# Altium schematic sheet TD4-CORE.SchDoc(TD4-CORE)
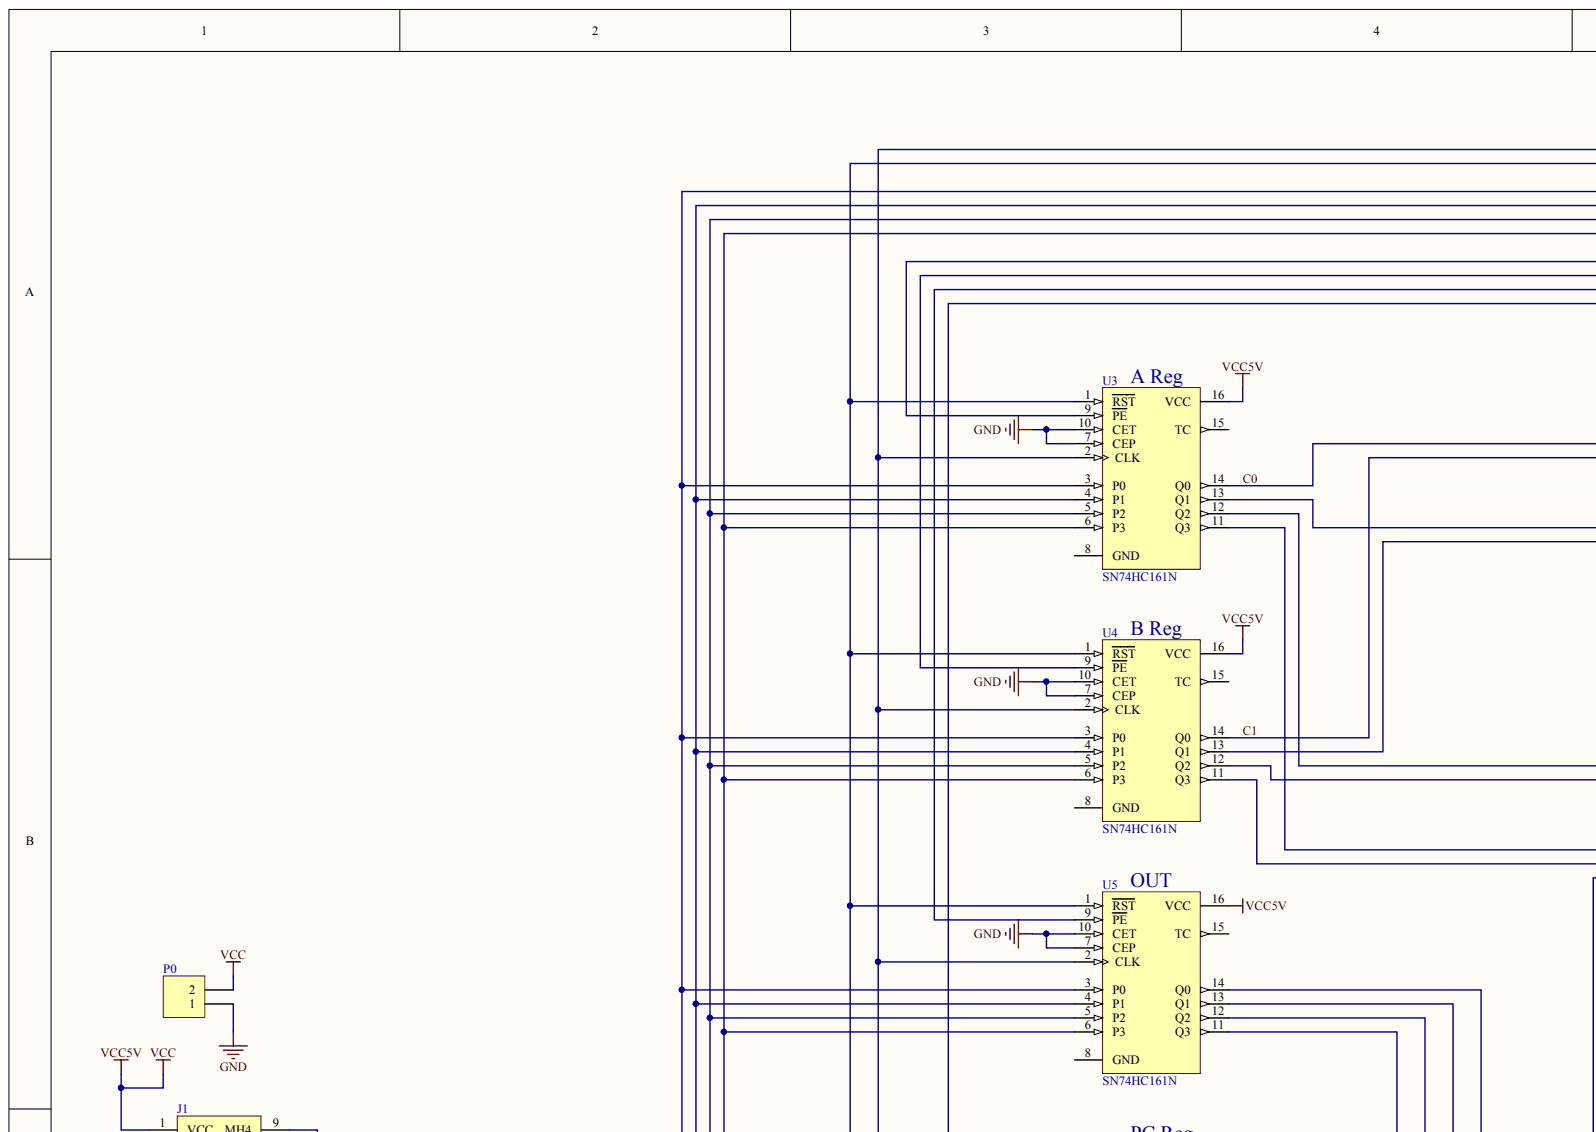
[diagram p0: TD4-CORE.SchDoc, top left]
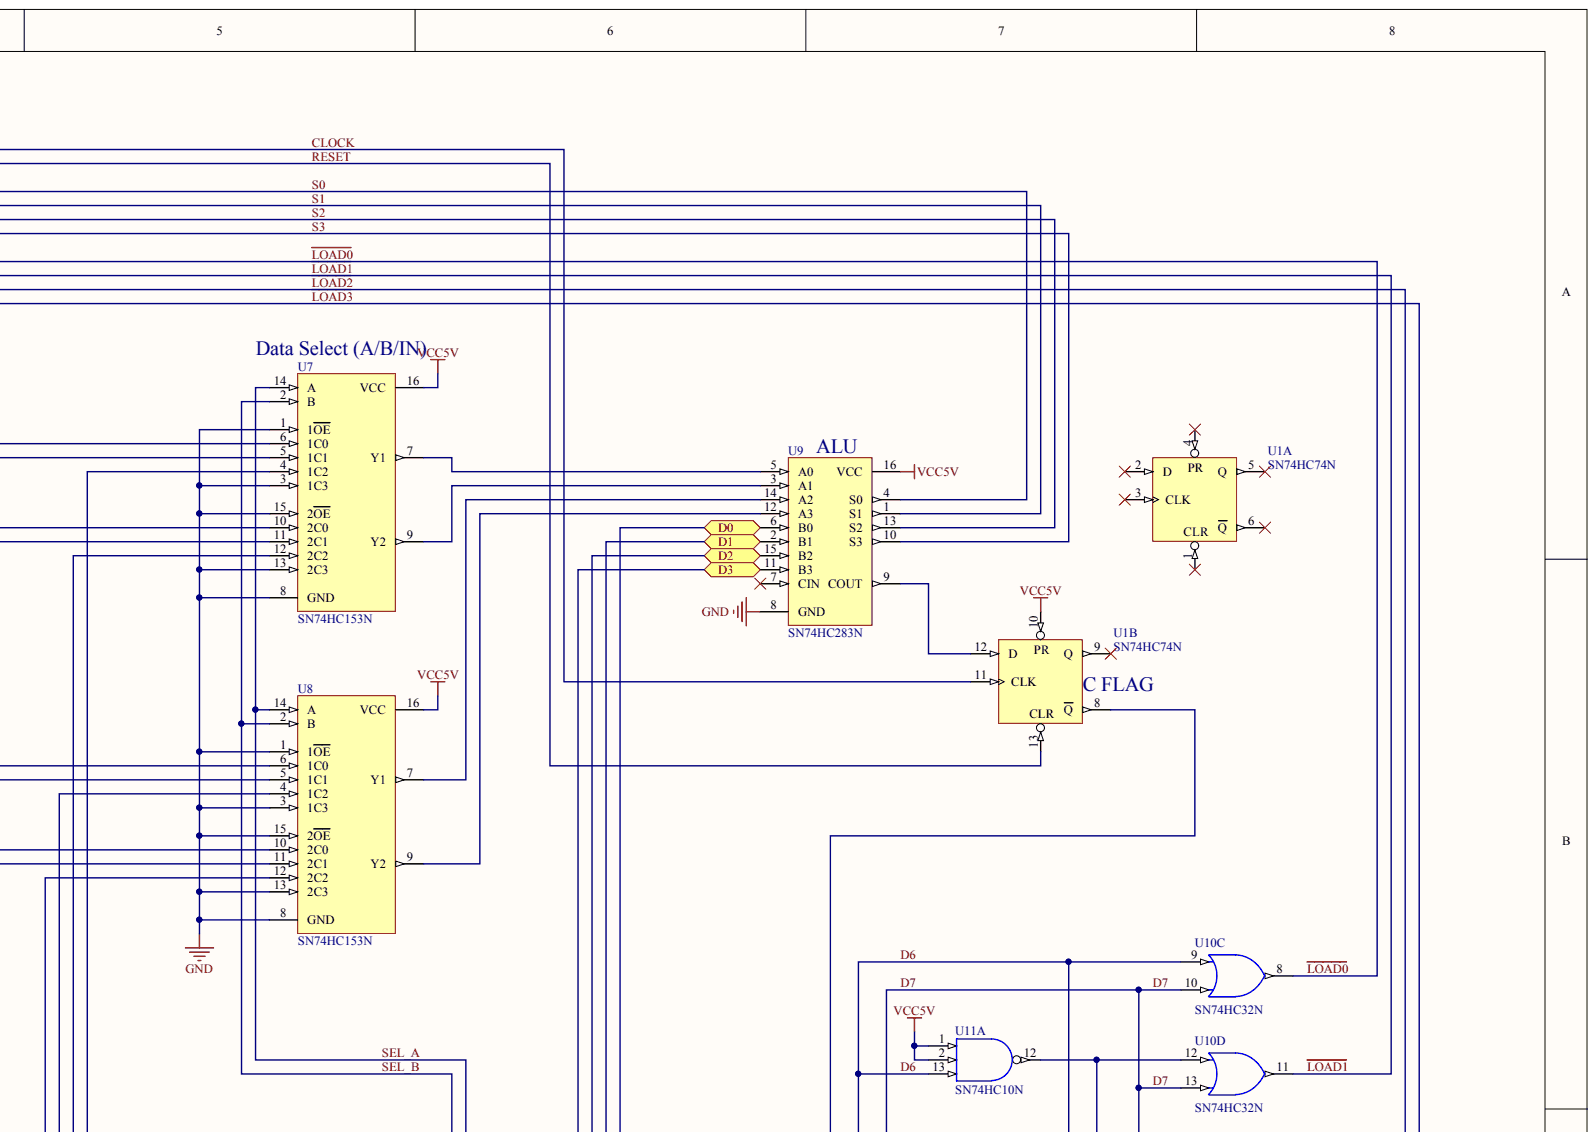
[diagram p1: TD4-CORE.SchDoc, top right]
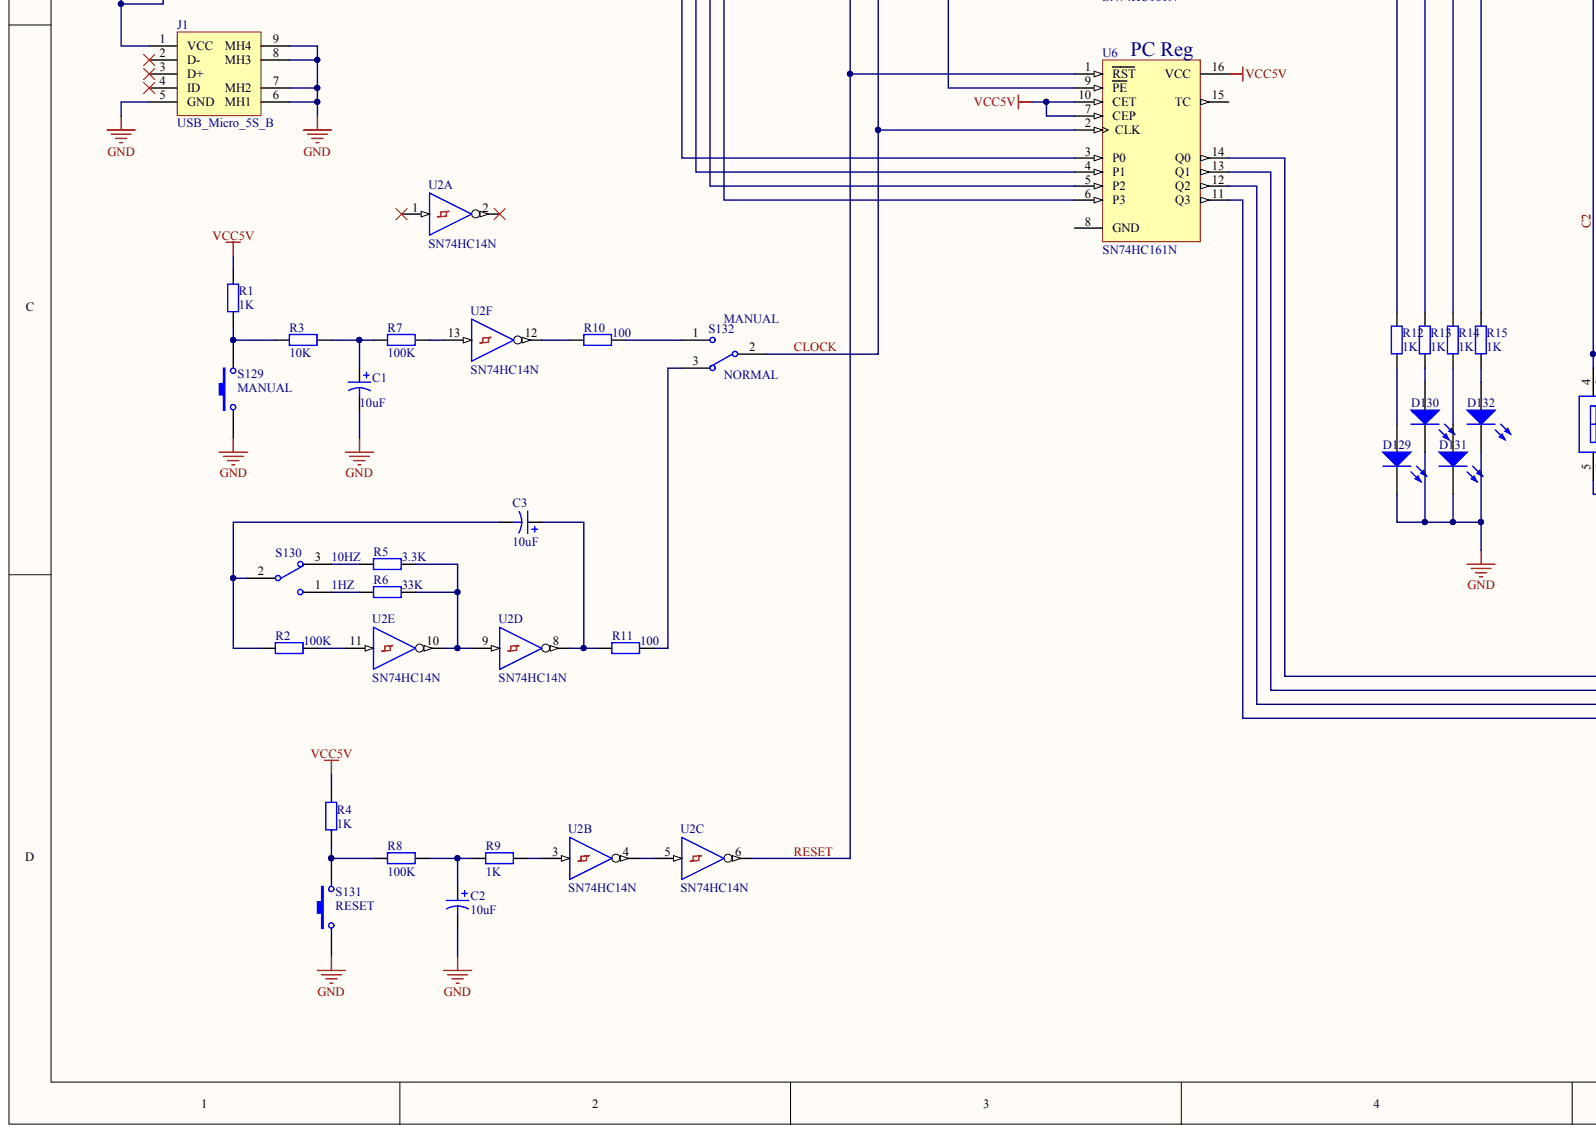
[diagram p2: TD4-CORE.SchDoc, bottom left]
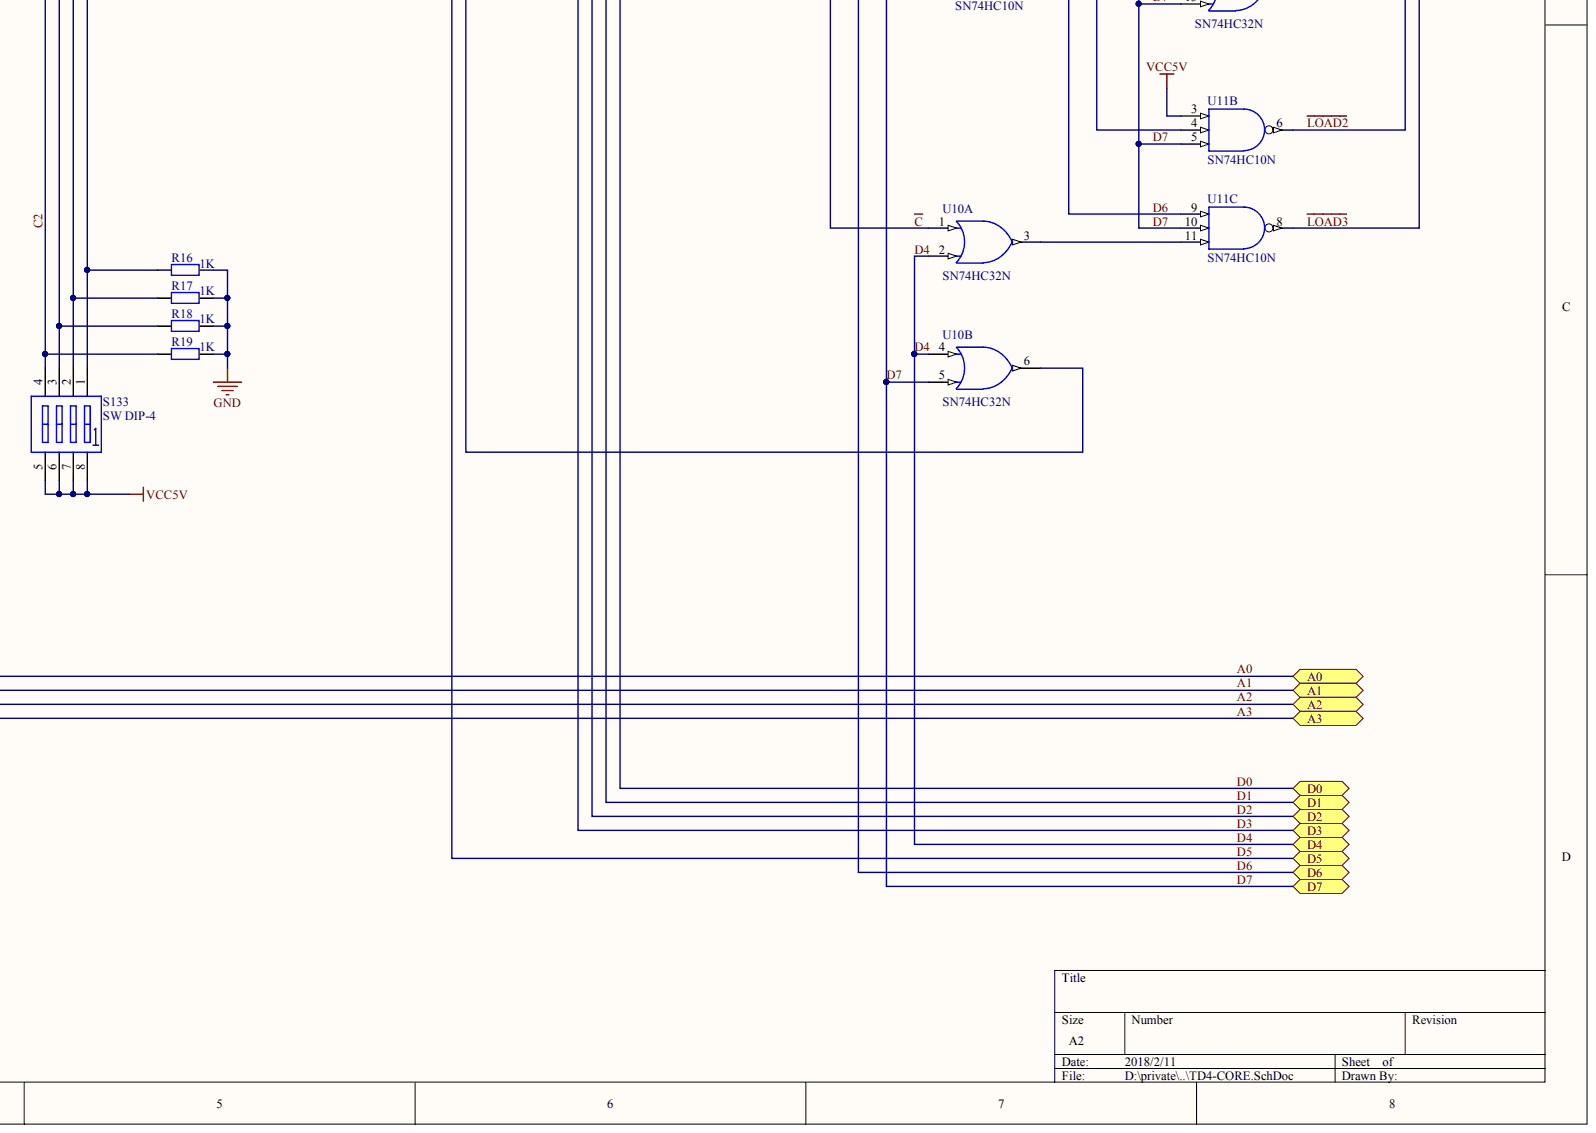
[diagram p3: TD4-CORE.SchDoc, bottom right]

## Parts
C1  value 10uF  [CAP1.5_4x7]  (hidden comment: Cap Pol1)
C2  value 10uF  [CAP1.5_4x7]  (hidden comment: Cap Pol1)
C3  value 10uF  [CAP1.5_4x7]  (hidden comment: Cap Pol1)
D129  LED0  [LED]  (value not drawn)
D130  LED0  [LED]  (value not drawn)
D131  LED0  [LED]  (value not drawn)
D132  LED0  [LED]  (value not drawn)
J1  USB_Micro_5S_B  [USB_MICRO_5S_B]
P0  Header 2  [HDR1X2]  (value not drawn)
R1  value 1K  [AXIAL-0.4]  (hidden comment: Res2)
R2  value 100K  [AXIAL-0.4]  (hidden comment: Res2)
R3  value 10K  [AXIAL-0.4]  (hidden comment: Res2)
R4  value 1K  [AXIAL-0.4]  (hidden comment: Res2)
R5  value 3.3K  [AXIAL-0.4]  (hidden comment: Res2)
R6  value 33K  [AXIAL-0.4]  (hidden comment: Res2)
R7  value 100K  [AXIAL-0.4]  (hidden comment: Res2)
R8  value 100K  [AXIAL-0.4]  (hidden comment: Res2)
R9  value 1K  [AXIAL-0.4]  (hidden comment: Res2)
R10  value 100  [AXIAL-0.4]  (hidden comment: Res2)
R11  value 100  [AXIAL-0.4]  (hidden comment: Res2)
R12  value 1K  [AXIAL-0.4]  (hidden comment: Res2)
R13  value 1K  [AXIAL-0.4]  (hidden comment: Res2)
R14  value 1K  [AXIAL-0.4]  (hidden comment: Res2)
R15  value 1K  [AXIAL-0.4]  (hidden comment: Res2)
R16  value 1K  [AXIAL-0.4]  (hidden comment: Res2)
R17  value 1K  [AXIAL-0.4]  (hidden comment: Res2)
R18  value 1K  [AXIAL-0.4]  (hidden comment: Res2)
R19  value 1K  [AXIAL-0.4]  (hidden comment: Res2)
S129  MANUAL  [TSW DIP-6*6*6]
S130  SW-SPDT  [SS-12F23]  (value not drawn)
S131  RESET  [TSW DIP-6*6*6]
S132  SW-SPDT  [SS-12F23]  (value not drawn)
S133  SW DIP-4  [CSW DIP-4P]
U1  SN74HC74N  [N014]  (parts A,B)
U2  SN74HC14N  [N014]  (parts A,B,C,D,E,F)
U3  SN74HC161N  [N016]
U4  SN74HC161N  [N016]
U5  SN74HC161N  [N016]
U6  SN74HC161N  [N016]
U7  SN74HC153N  [N016]
U8  SN74HC153N  [N016]
U9  SN74HC283N  [N016]
U10  SN74HC32N  [N014]  (parts A,B,C,D)
U11  SN74HC10N  [N014]  (parts A,B,C)

## Ports
A0, A1, A2, A3, D0, D1, D2, D3, D4, D5, D6, D7

## Nets
GND: C1.2 C2.2 D129.2 D130.2 D131.2 D132.2 J1.5(GND) J1.6(MH1) J1.7(MH2) J1.8(MH3) J1.9(MH4) P0.1 R16.2 R17.2 R18.2 R19.2 S129.1 S131.1 U1.7 U2.7 U3.7(CEP) U3.10(CET) U4.7(CEP) U4.10(CET) U5.7(CEP) U5.10(CET) U7.1(1OE) U7.3(1C3) U7.8(GND) U7.13(2C3) U7.15(2OE) U8.1(1OE) U8.3(1C3) U8.8(GND) U8.13(2C3) U8.15(2OE) U9.8(GND) U10.7 U11.7
VCC: J1.1(VCC) P0.2 R1.1 R4.1 S133.5 S133.6 S133.7 S133.8 U1.10(PR) U1.14 U2.14 U3.16(VCC) U4.16(VCC) U5.16(VCC) U6.7(CEP) U6.10(CET) U6.16(VCC) U7.16(VCC) U8.16(VCC) U9.16(VCC) U10.14 U11.1 U11.2 U11.3 U11.14
A0: U6.14(Q0) ; port A0
A1: U6.13(Q1) ; port A1
A2: U6.12(Q2) ; port A2
A3: U6.11(Q3) ; port A3
C0: U3.14(Q0) U7.6(1C0)
C1: U4.14(Q0) U7.5(1C1)
C2: R19.1 S133.4 U8.12(2C2)
~{C}: U1.8(Q) U10.1
CLOCK: S132.2 U1.11(CLK) U3.2(CLK) U4.2(CLK) U5.2(CLK) U6.2(CLK)
D0: U9.6(B0) ; port D0
D1: U9.2(B1) ; port D1
D2: U9.15(B2) ; port D2
D3: U9.11(B3) ; port D3
D4: U10.2 U10.4 ; port D4
D5: U7.2(B) U8.2(B) ; port D5
D6: U10.9 U11.9 U11.13 ; port D6
D7: U10.5 U10.10 U10.13 U11.5 U11.10 ; port D7
~{LOAD0}: U3.9(PE) U10.8
~{LOAD1}: U4.9(PE) U10.11
~{LOAD2}: U5.9(PE) U11.6
~{LOAD3}: U6.9(PE) U11.8
RESET: U1.13 U2.6 U3.1(RST) U4.1(RST) U5.1(RST) U6.1(RST)
S0: U3.3(P0) U4.3(P0) U5.3(P0) U6.3(P0) U9.4(S0)
S1: U3.4(P1) U4.4(P1) U5.4(P1) U6.4(P1) U9.1(S1)
S2: U3.5(P2) U4.5(P2) U5.5(P2) U6.5(P2) U9.13(S2)
S3: U3.6(P3) U4.6(P3) U5.6(P3) U6.6(P3) U9.10(S3)
SEL_A: U7.14(A) U8.14(A) U10.6
NetC1_1: C1.1 R3.2 R7.1
NetC2_1: C2.1 R8.2 R9.1
NetC3_1: C3.1 R11.1 U2.8
NetC3_2: C3.2 R2.1 S130.2
NetD129_1: D129.1 R12.2
NetD130_1: D130.1 R13.2
NetD131_1: D131.1 R14.2
NetD132_1: D132.1 R15.2
NetR1_2: R1.2 R3.1 S129.2
NetR2_2: R2.2 U2.11
NetR4_2: R4.2 R8.1 S131.2
NetR5_1: R5.1 S130.3
NetR5_2: R5.2 R6.2 U2.9 U2.10
NetR6_1: R6.1 S130.1
NetR7_2: R7.2 U2.13
NetR9_2: R9.2 U2.3
NetR10_1: R10.1 U2.12
NetR10_2: R10.2 S132.1
NetR11_2: R11.2 S132.3
NetR12_1: R12.1 U5.11(Q3)
NetR13_1: R13.1 U5.12(Q2)
NetR14_1: R14.1 U5.13(Q1)
NetR15_1: R15.1 U5.14(Q0)
NetR16_1: R16.1 S133.1 U7.4(1C2)
NetR17_1: R17.1 S133.2 U7.12(2C2)
NetR18_1: R18.1 S133.3 U8.4(1C2)
NetU1_12: U1.12(D) U9.9(COUT)
NetU2_4: U2.4 U2.5
NetU3_11: U3.11(Q3) U8.10(2C0)
NetU3_12: U3.12(Q2) U8.6(1C0)
NetU3_13: U3.13(Q1) U7.10(2C0)
NetU4_11: U4.11(Q3) U8.11(2C1)
NetU4_12: U4.12(Q2) U8.5(1C1)
NetU4_13: U4.13(Q1) U7.11(2C1)
NetU7_7: U7.7(Y1) U9.5(A0)
NetU7_9: U7.9(Y2) U9.3(A1)
NetU8_7: U8.7(Y1) U9.14(A2)
NetU8_9: U8.9(Y2) U9.12(A3)
NetU10_3: U10.3 U11.11
NetU10_12: U10.12 U11.4 U11.12

Not connected: J1 2(D-) 3(D+) 4(ID); U1 1 2(D) 3(CLK) 4(PR) 5(Q) 6(Q) 9(Q); U2 1 2; U3 8(GND) 15(TC); U4 8(GND) 15(TC); U5 8(GND) 15(TC); U6 8(GND) 15(TC); U9 7(CIN)

## Library data of the parts
C1-C3 = Cap Pol1: Polarized Capacitor (Radial); Component Kind: Standard; LatestRevisionDate: 17-Jul-2002; LatestRevisionNote: Re-released for DXP Platform.; Library Name: Miscellaneous Devices.IntLib; PackageDescription: Polarized Capacitor (Radial); 2 Leads; PackageReference: RB7.6-15; Published: 8-Jun-2000; Publisher: Altium Limited
D129-D132 = LED0: Typical INFRARED GaAs LED; Component Kind: Standard; LatestRevisionDate: 08-Jul-2005; LatestRevisionNote: Mech Layer 1 removed.; Library Name: Miscellaneous Devices.IntLib; PackageDescription: LED; 2 Leads; PackageReference: LED-0; Published: 8-Jun-2000; Simulation: LED0; Publisher: Altium Limited
P0 = Header 2: Header, 2-Pin; Component Kind: Standard; LatestRevisionDate: 17-Jul-2002; LatestRevisionNote: Re-released for DXP Platform.; Library Name: Miscellaneous Connectors.IntLib; Signal Integrity: Connector; Publisher: Altium Limited
R1-R19 = Res2: Resistor; Component Kind: Standard; LatestRevisionDate: 17-Jul-2002; LatestRevisionNote: Re-released for DXP Platform.; Library Name: Miscellaneous Devices.IntLib; PackageDescription: Axial Device, Thru-Hole; 2 Leads; 0.4 in Pin Spacing; PackageReference: AXIAL-0.4; Published: 8-Jun-2000; Signal Integrity: Res; Simulation: RESISTOR; Publisher: Altium Limited
S129 S131 = SW-PB: Switch; Component Kind: Standard; LatestRevisionDate: 17-Jul-2002; LatestRevisionNote: Re-released for DXP Platform.; Library Name: Miscellaneous Devices.IntLib; PackageDescription: Switch; 2 Leads; PackageReference: SPST-2; Published: 24-Mar-1999; Publisher: Altium Limited
S130 S132 = SW-SPDT: SPDT Subminiature Toggle Switch, Right Angle Mounting, Vertical Actuation; Component Kind: Standard; ComponentLink1Description: Manufacturer Link; ComponentLink1URL: http://www.apem.com; DatasheetVersion: 05-Dec-2000; LatestRevisionDate: 17-Dec-2003; LatestRevisionNote: Schematic revised, PCB Footprint replaced.; Library Name: Miscellaneous Devices.IntLib; PackageDescription: Switch; 4 Leads; PackageReference: TL36WW15050; Published: 24-Mar-1999; Publisher: Altium Limited
S133 = SW DIP-4: DIP Switch, 4 Position, SPST; Code_IPC: SOIC127P600-8; Code_JEDEC: MS-012-AA; Component Kind: Standard; LatestRevisionDate: 29-May-2009; LatestRevisionNote: IPC-7351 Footprint Added.; Library Name: Miscellaneous Devices.IntLib; PackageDescription: 8-Pin Small Outline Integrated Circuit 1.27 mm Pitch; PackageReference: SO8; PackageVersion: Dec-2002; Published: 24-Mar-1999; Publisher: Altium Limited
U1 = SN74HC74N: Dual D-Type Positive-Edge-Triggered Flip-Flop with Clear and Preset; Component Kind: Standard; ComponentLink1Description: Manufacturer Link; ComponentLink1URL: http://www.ti.com/; ComponentLink2Description: Datasheet; ComponentLink2URL: http://www-s.ti.com/sc/ds/sn74hc74.pdf; DatasheetVersion: May-1997; LatestRevisionDate: 04-Mar-2005; LatestRevisionNote: Stylized 3D Model Added.; Library Name: TI Logic Flip-Flop.IntLib; PackageDescription: DIP; 14 Leads; Row Spacing 7.62 mm; Pitch 2.54 mm; PackageReference: N014; PackageVersion: Oct-1995; PCB3D: N014; Published: 8-Jun-2000; Signal Integrity: SN74HC74N; Publisher: Altium Limited
U2 = SN74HC14N: Hex Schmitt-Trigger Inverter; Component Kind: Standard; ComponentLink1Description: Manufacturer Link; ComponentLink1URL: http://www.ti.com/; ComponentLink2Description: Datasheet; ComponentLink2URL: http://www-s.ti.com/sc/ds/sn74hc14.pdf; DatasheetVersion: May-1997; LatestRevisionDate: 04-Mar-2005; LatestRevisionNote: Stylized 3D Model Added.; Library Name: TI Logic Gate 2.IntLib; PackageDescription: DIP; 14 Leads; Row Spacing 7.62 mm; Pitch 2.54 mm; PackageReference: N014; PackageVersion: Oct-1995; PCB3D: N014; Published: 8-Jun-2000; Signal Integrity: SN74HC14N; Publisher: Altium Limited
U3-U6 = SN74HC161N: 4-Bit Synchronous Binary Counter; Code_JEDEC: MS-001-BB; Component Kind: Standard; ComponentLink1Description: Manufacturer Link; ComponentLink1URL: http://www.ti.com/; ComponentLink2Description: Datasheet; ComponentLink2URL: http://www-s.ti.com/sc/ds/sn74hc161.pdf; DatasheetVersion: May-1997; LatestRevisionDate: 04-Mar-2005; LatestRevisionNote: Stylized 3D Model Added.; Library Name: TI Logic Counter.IntLib; PackageDescription: DIP; 16 Leads; Row Spacing 7.62 mm; Pitch 2.54 mm; PackageReference: N016; PackageVersion: Oct-1995; PCB3D: N016; Published: 8-Jun-2000; Signal Integrity: SN74HC161N; Publisher: Altium Limited
U7 U8 = SN74HC153N: Dual 4-Line to 1-Line Data Selector/Multiplexer; Code_JEDEC: MS-001-BB; Component Kind: Standard; ComponentLink1Description: Manufacturer Link; ComponentLink1URL: http://www.ti.com/; ComponentLink2Description: Datasheet; ComponentLink2URL: http://www-s.ti.com/sc/ds/sn74hc153.pdf; DatasheetVersion: May-1997; LatestRevisionDate: 04-Mar-2005; LatestRevisionNote: Stylized 3D Model Added.; Library Name: TI Logic Multiplexer.IntLib; PackageDescription: DIP; 16 Leads; Row Spacing 7.62 mm; Pitch 2.54 mm; PackageReference: N016; PackageVersion: Oct-1995; PCB3D: N016; Published: 8-Jun-2000; Signal Integrity: SN74HC153N; Publisher: Altium Limited
U9 = SN74HC283N: 4-Bit Binary Full Adder with Fast Carry; ComponentAvailability: Obsolete; Code_JEDEC: MS-001-BB; Component Kind: Standard; ComponentLink1Description: Manufacturer Link; ComponentLink1URL: http://www.ti.com; ComponentLink2Description: Datasheet; ComponentLink2URL: http://www-s.ti.com/sc/ds/sn74hc283.pdf; DatasheetVersion: Sep-1987; LatestRevisionDate: 04-Mar-2005; LatestRevisionNote: Stylized 3D Model Added.; PackageDescription: DIP; 16 Leads; Row Spacing 7.62 mm; Pitch 2.54 mm; PackageReference: N016; PackageVersion: Oct-1995; PCB3D: N016; Published: 8-Jun-2000; Signal Integrity: SN74HC283N; Library Name: TI Logic Arithmetic.IntLib; Publisher: Altium Limited
U10 = SN74HC32N: Quadruple 2-Input Positive-OR Gate; Component Kind: Standard; ComponentLink1Description: Manufacturer Link; ComponentLink1URL: http://www.ti.com/; ComponentLink2Description: Datasheet; ComponentLink2URL: http://www-s.ti.com/sc/ds/sn74hc32.pdf; DatasheetVersion: May-1997; LatestRevisionDate: 04-Mar-2005; LatestRevisionNote: Stylized 3D Model Added.; Library Name: TI Logic Gate 2.IntLib; PackageDescription: DIP; 14 Leads; Row Spacing 7.62 mm; Pitch 2.54 mm; PackageReference: N014; PackageVersion: Oct-1995; PCB3D: N014; Published: 8-Jun-2000; Signal Integrity: SN74HC32N; Publisher: Altium Limited
U11 = SN74HC10N: Triple 3-Input Positive-NAND Gate; Component Kind: Standard; ComponentLink1Description: Manufacturer Link; ComponentLink1URL: http://www.ti.com/; ComponentLink2Description: Datasheet; ComponentLink2URL: http://www-s.ti.com/sc/ds/sn74hc10.pdf; DatasheetVersion: May-1997; LatestRevisionDate: 04-Mar-2005; LatestRevisionNote: Stylized 3D Model Added.; Library Name: TI Logic Gate 2.IntLib; PackageDescription: DIP; 14 Leads; Row Spacing 7.62 mm; Pitch 2.54 mm; PackageReference: N014; PackageVersion: Oct-1995; PCB3D: N014; Published: 8-Jun-2000; Signal Integrity: SN74HC10N; Publisher: Altium Limited
J1 = USB_Micro_5S_B: Component Kind: Standard; Library Name: TD4.SchLib
